# Altium schematic sheet [11]DriverDribbler.SchDoc
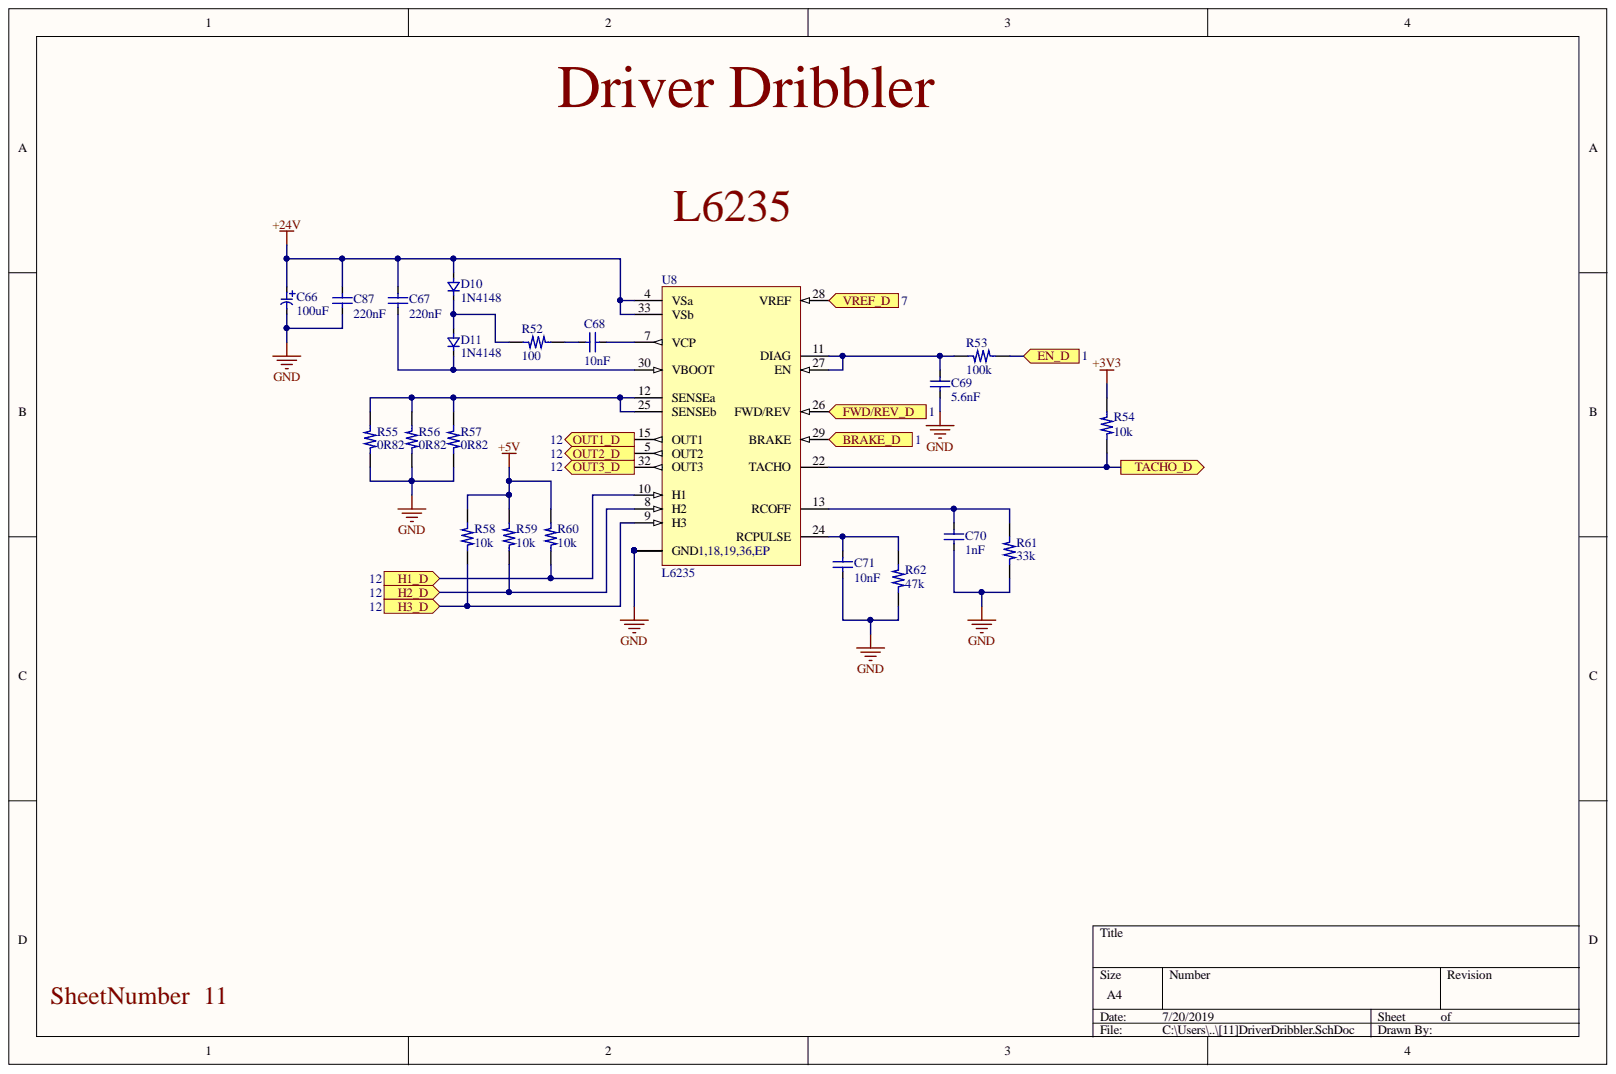
[diagram p0: [11]DriverDribbler.SchDoc]

## Parts
C66  100uF  [Radial SMD 10D]
C67  220nF  [C0805]
C68  10nF  [C0805]
C69  5.6nF  [C0805]
C70  1nF  [C0805]
C71  10nF  [C0805]
C87  220nF  [C0805]
D10  1N4148  [SOD-123]
D11  1N4148  [SOD-123]
R52  100  [R0805]
R53  100k  [R0805]
R54  10k  [R0805]
R55  0R82  [R4122]
R56  0R82  [R4122]
R57  0R82  [R4122]
R58  10k  [R0805]
R59  10k  [R0805]
R60  10k  [R0805]
R61  33k  [R0805]
R62  47k  [R0805]
U8  L6235  [POWERSO36]

## Ports
BRAKE_D, EN_D, FWD/REV_D, H1_D, H2_D, H3_D, OUT1_D, OUT2_D, OUT3_D, TACHO_D, VREF_D

## Nets
+3V3: R54.2
+5V: R58.1 R59.1 R60.1
+24V: C66.1 C67.2 C87.2 D10.1 U8.4(VSa) U8.33(VSb)
GND: C66.2 C69.2 C70.1 C71.1 C87.1 R55.1 R56.1 R57.1 R61.1 R62.1 U8.1 U8.18 U8.19 U8.36 U8.37
BRAKE_D: U8.29(BRAKE) ; port BRAKE_D
EN_D: R53.2 ; port EN_D
FWD/REV_D: U8.26(FWD/REV) ; port FWD/REV_D
H1_D: R60.2 U8.10(H1) ; port H1_D
H2_D: R59.2 U8.8(H2) ; port H2_D
H3_D: R58.2 U8.9(H3) ; port H3_D
OUT1_D: U8.15(OUT1) ; port OUT1_D
OUT2_D: U8.5(OUT2) ; port OUT2_D
OUT3_D: U8.32(OUT3) ; port OUT3_D
TACHO_D: R54.1 U8.22(TACHO) ; port TACHO_D
VREF_D: U8.28(VREF) ; port VREF_D
NetC67_1: C67.1 D11.2 U8.30(VBOOT)
NetC68_1: C68.1 R52.2
NetC68_2: C68.2 U8.7(VCP)
NetC69_1: C69.1 R53.1 U8.11(DIAG) U8.27(EN)
NetC70_2: C70.2 R61.2 U8.13(RCOFF)
NetC71_2: C71.2 R62.2 U8.24(RCPULSE)
NetD10_2: D10.2 D11.1 R52.1
NetR55_2: R55.2 R56.2 R57.2 U8.12(SENSEa) U8.25(SENSEb)

## Library data of the parts
C66 = EEE1JA101P: CAP ALUM 100UF 20% 63V SMD; Mfr 1: Panasonic Electronic Components; Mfr 1 PN: EEE-1JA101P; Component Kind: Standard; Library Name: Components.SchLib
C67 C87 = C0805C224K5RACAUTO: CAP CER 0.22UF 50V X7R 0805; Mfr 1: KEMET; Component Kind: Standard; Library Name: Components.SchLib; Mfr 1 PN: C0805C224K5RACAUTO
C68 C71 = CL21B103KBANFNC: CAP CER 10000PF 50V X7R 0805; Mfr 1: Samsung Electro-Mechanics; Component Kind: Standard; Library Name: Components.SchLib; Mfr 1 PN: CL21B103KBANFNC
C69 = CL21B562KBANNNC: CAP CER 5600PF 50V X7R 0805; Mfr 1: Samsung Electro-Mechanics; Component Kind: Standard; Library Name: Components.SchLib; Mfr 1 PN: CL21B562KBANNNC
C70 = CL21B102KBANFNC: CAP CER 1000PF 50V X7R 0805; Mfr 1: Samsung Electro-Mechanics; Component Kind: Standard; Library Name: Components.SchLib; Mfr 1 PN: CL21B102KBANFNC
D10 D11 = 1N4148W-G RHG: DIODE GEN PURP 100V 150MA SOD123; Mfr 1: Taiwan Semiconductor Corporation; Component Kind: Standard; Library Name: Components.SchLib; Mfr 1 PN: 1N4148W-G RHG
R52 = ESR10EZPF1000: RES SMD 100 OHM 1% 0.4W 0805; Mfr 1: Rohm Semiconductor; Component Kind: Standard; Library Name: Components.SchLib; Mfr 1 PN: ESR10EZPF1000
R53 = RC0805FR-07100KL: RES SMD 100K OHM 1% 1/8W 0805; Mfr 1: Yageo; Component Kind: Standard; Library Name: Components.SchLib; Mfr 1 PN: RC0805FR-07100KL
R54 = CRG0805F10K/10: RES SMD 10K OHM 1% 1/8W 0805; Mfr 1: TE Connectivity Passive Product; Component Kind: Standard; Library Name: Components.SchLib; Mfr 1 PN: CRG0805F10K/10
R55-R57 = SMW3R82JT: RES 0.82 OHM 5% 3W 4122; Mfr 1: TE Connectivity Passive Product; Component Kind: Standard; Library Name: Components.SchLib; Mfr 1 PN: SMW3R82JT
R58-R60 = RC0805FR-0710KL: RES SMD 10K OHM 1% 1/8W 0805; Mfr 1: Yageo; Component Kind: Standard; Library Name: Components.SchLib; Mfr 1 PN: RC0805FR-0710KL
R61 = RMCF0805FT33K0: RES 33K OHM 1% 1/8W 0805; Mfr 1: Stackpole Electronics Inc.; Component Kind: Standard; Library Name: Components.SchLib; Mfr 1 PN: RMCF0805FT33K0
R62 = RC0805FR-0747KL: RES SMD 47K OHM 1% 1/8W 0805; Mfr 1: Yageo; Component Kind: Standard; Library Name: Components.SchLib; Mfr 1 PN: RC0805FR-0747KL
U8 = L6235PD: IC MOTOR DRIVER PAR 36POWERSO; Mfr 1: STMicroelectronics; Component Kind: Standard; Library Name: Components.SchLib; Mfr 1 PN: L6235PD
